# Altium schematic sheet MCU.SchDoc(MCU)
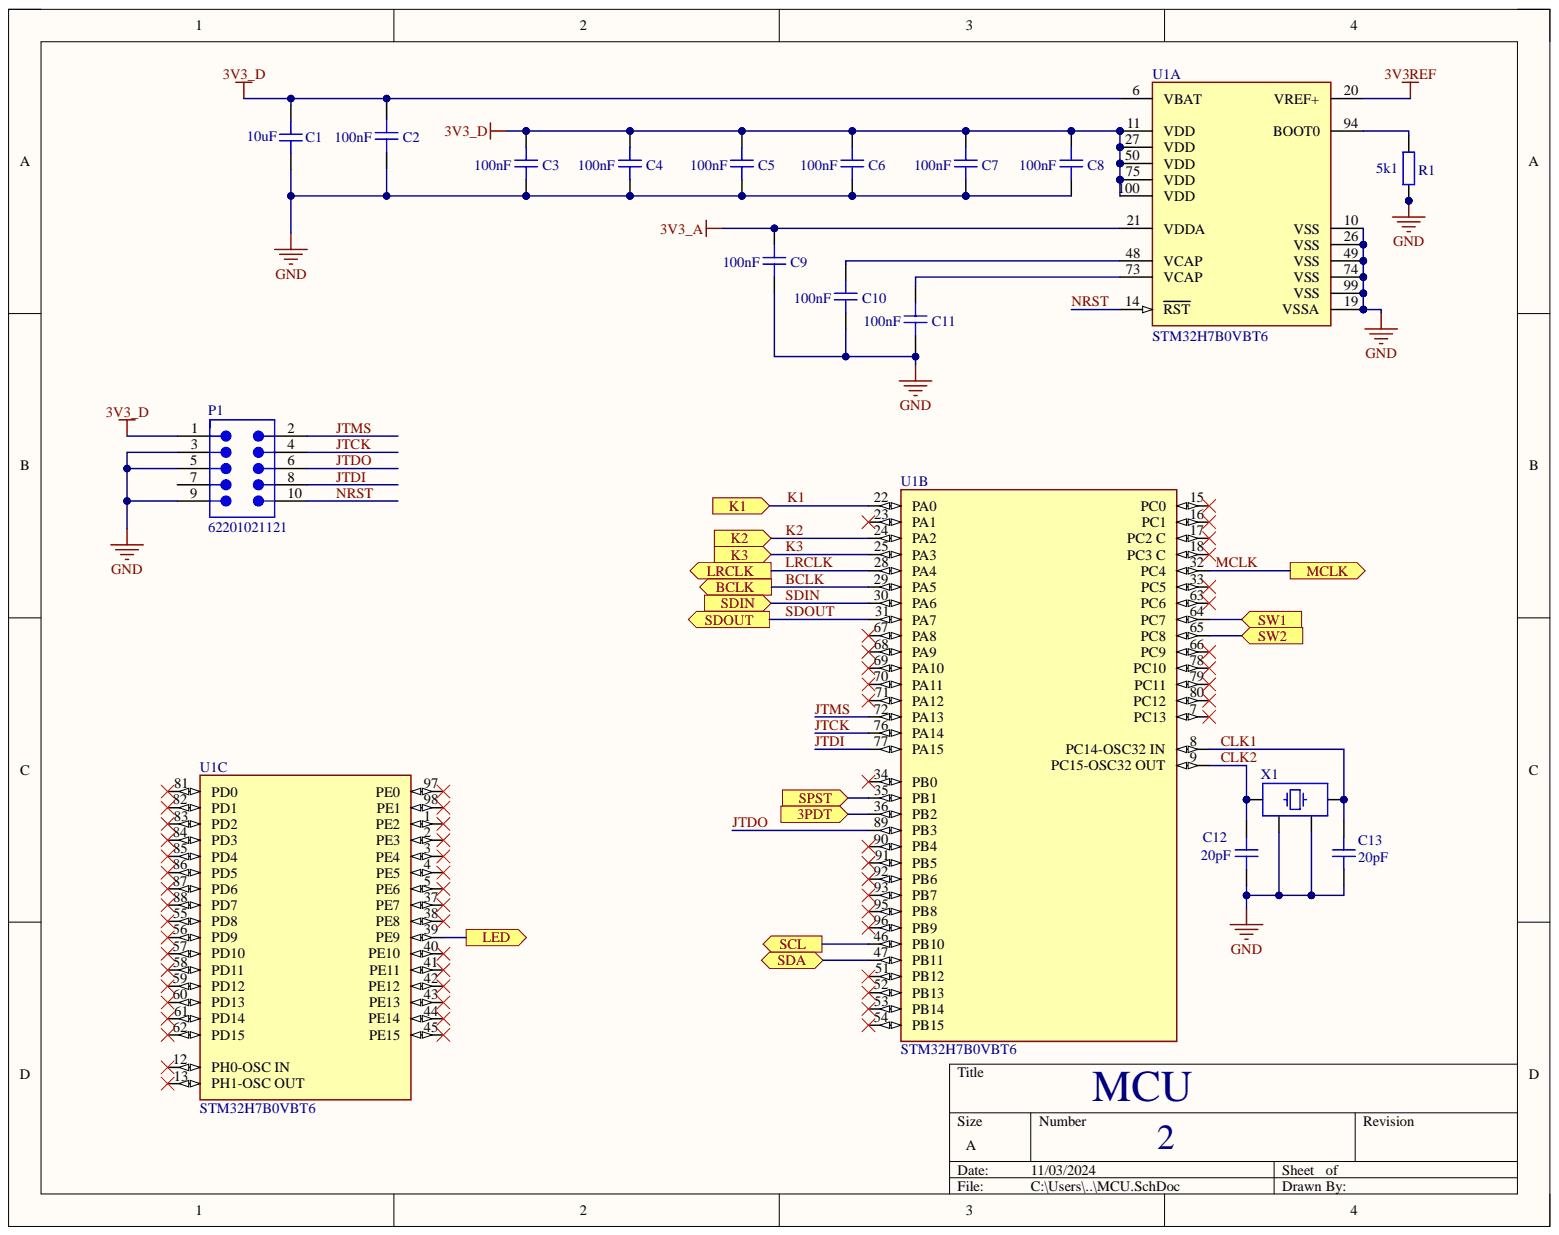
[diagram p0: MCU.SchDoc]

## Parts
C1  10uF  [CAP_0805]
C2  100nF  [CAP_0805]
C3  100nF  [CAP_0805]
C4  100nF  [CAP_0805]
C5  100nF  [CAP_0805]
C6  100nF  [CAP_0805]
C7  100nF  [CAP_0805]
C8  100nF  [CAP_0805]
C9  100nF  [CAP_0805]
C10  100nF  [CAP_0805]
C11  100nF  [CAP_0805]
C12  20pF  [CAP_0805]
C13  20pF  [CAP_0805]
P1  62201021121  [62201021121]
R1  5k1  [RES_0805]
U1  STM32H7B0VBT6  [FP-LQFP100-IPC_A]  (parts A,B,C)
X1  TSX-3225_24.0000MF15X-AC  [XTAL_3225]  (value not drawn)

## Ports
3PDT, BCLK, K1, K2, K3, LED, LRCLK, MCLK, SCL, SDA, SDIN, SDOUT, SPST, SW1, SW2

## Nets
3V3_A: C9.2 U1.21(VDDA)
3V3_D: C1.2 C2.2 C3.2 C4.2 C5.2 C6.2 C7.2 C8.2 P1.1 U1.6(VBAT) U1.11(VDD) U1.27(VDD) U1.50(VDD) U1.75(VDD) U1.100(VDD)
3V3REF: U1.20(VREF+)
GND: C1.1 C2.1 C3.1 C4.1 C5.1 C6.1 C7.1 C8.1 C9.1 C10.1 C11.1 C12.1 C13.1 P1.3 P1.5 P1.9 R1.1 U1.10(VSS) U1.19(VSSA) U1.26(VSS) U1.49(VSS) U1.74(VSS) U1.99(VSS) X1.2 X1.4
3PDT: U1.36(PB2) ; port 3PDT
BCLK: U1.29(PA5) ; port BCLK
CLK1: C13.2 U1.8(PC14-OSC32_IN) X1.3
CLK2: C12.2 U1.9(PC15-OSC32_OUT) X1.1
JTCK: P1.4 U1.76(PA14)
JTDI: P1.8 U1.77(PA15)
JTDO: P1.6 U1.89(PB3)
JTMS: P1.2 U1.72(PA13)
K1: U1.22(PA0) ; port K1
K2: U1.24(PA2) ; port K2
K3: U1.25(PA3) ; port K3
LED: U1.39(PE9) ; port LED
LRCLK: U1.28(PA4) ; port LRCLK
MCLK: U1.32(PC4) ; port MCLK
NRST: P1.10 U1.14(RST)
SCL: U1.46(PB10) ; port SCL
SDA: U1.47(PB11) ; port SDA
SDIN: U1.30(PA6) ; port SDIN
SDOUT: U1.31(PA7) ; port SDOUT
SPST: U1.35(PB1) ; port SPST
SW1: U1.64(PC7) ; port SW1
SW2: U1.65(PC8) ; port SW2
NetC10_2: C10.2 U1.48(VCAP)
NetC11_2: C11.2 U1.73(VCAP)
NetR1_2: R1.2 U1.94(BOOT0)

Not connected: P1 7; U1 1(PE2) 2(PE3) 3(PE4) 4(PE5) 5(PE6) 7(PC13) 12(PH0-OSC_IN) 13(PH1-OSC_OUT) 15(PC0) 16(PC1) 17(PC2_C) 18(PC3_C) 23(PA1) 33(PC5) 34(PB0) 37(PE7) 38(PE8) 40(PE10) 41(PE11) 42(PE12) 43(PE13) 44(PE14) 45(PE15) 51(PB12) 52(PB13) 53(PB14) 54(PB15) 55(PD8) 56(PD9) 57(PD10) 58(PD11) 59(PD12) 60(PD13) 61(PD14) 62(PD15) 63(PC6) 66(PC9) 67(PA8) 68(PA9) 69(PA10) 70(PA11) 71(PA12) 78(PC10) 79(PC11) 80(PC12) 81(PD0) 82(PD1) 83(PD2) 84(PD3) 85(PD4) 86(PD5) 87(PD6) 88(PD7) 90(PB4) 91(PB5) 92(PB6) 93(PB7) 95(PB8) 96(PB9) 97(PE0) 98(PE1)

## Library data of the parts
C1-C13 = CMP-001-00086-1: Capacitor SMD 0805; Component Kind: Standard; Design Item ID: CAP_0805; Library Name: Projects; Source: Schematic-Lib-PhilsLab.SchLib
P1 = CMP-1502-00948-3: NexarPartId: 32855586; Connector Type: Male Pin; Contact Resistance: 20mΩ; Current Rating: 1A; Gender: Male; Number of Contacts: 10; Operating Supply Voltage: 100V; Pitch: 1.27mm; Color: Black; Component Kind: Standard; Contact Material: Copper; Contact Mating Length: 3.81mm; Contact Plating: Gold; Height: 5.65mm; Lead Pitch: 1.27mm; Library Name: Altium Content Vault; Max Operating Temperature: 105°C; Min Operating Temperature: -40°C; Mount: Through Hole; Number of Pins: 10; Number of Positions: 10; Number of Rows: 2; Orientation: Vertical; Packaging: Box; REACH SVHC: Unknown; RoHS: Compliant; Row Spacing: 1.27mm; Termination: Solder; Voltage Rating: 100V
R1 = CMP-009-00180-1: Resistor SMD 0805; Component Kind: Standard; Design Item ID: RES_0805; Library Name: Projects; Source: Schematic-Lib-PhilsLab.SchLib
U1 = CMP-020-00026-1: RISC Microcontroller, 32-Bit, FLASH, CORTEX-M7 CPU, 280MHz, CMOS, PQFP100; Component Kind: Standard; Library Name: Projects
X1 = CMP-003-00033-1: Crystal SMD 24MHz 10PPM 7pF 3225; Manufacturer: Epson; Manufacturer Part Number: TSX-3225 24.0000MF15X-AC; Component Kind: Standard; Design Item ID: TSX-3225_24.0000MF15X-AC; Library Name: Projects; Source: Schematic-Lib-PhilsLab.SchLib
